# Altium schematic sheet ME5311.SchDoc
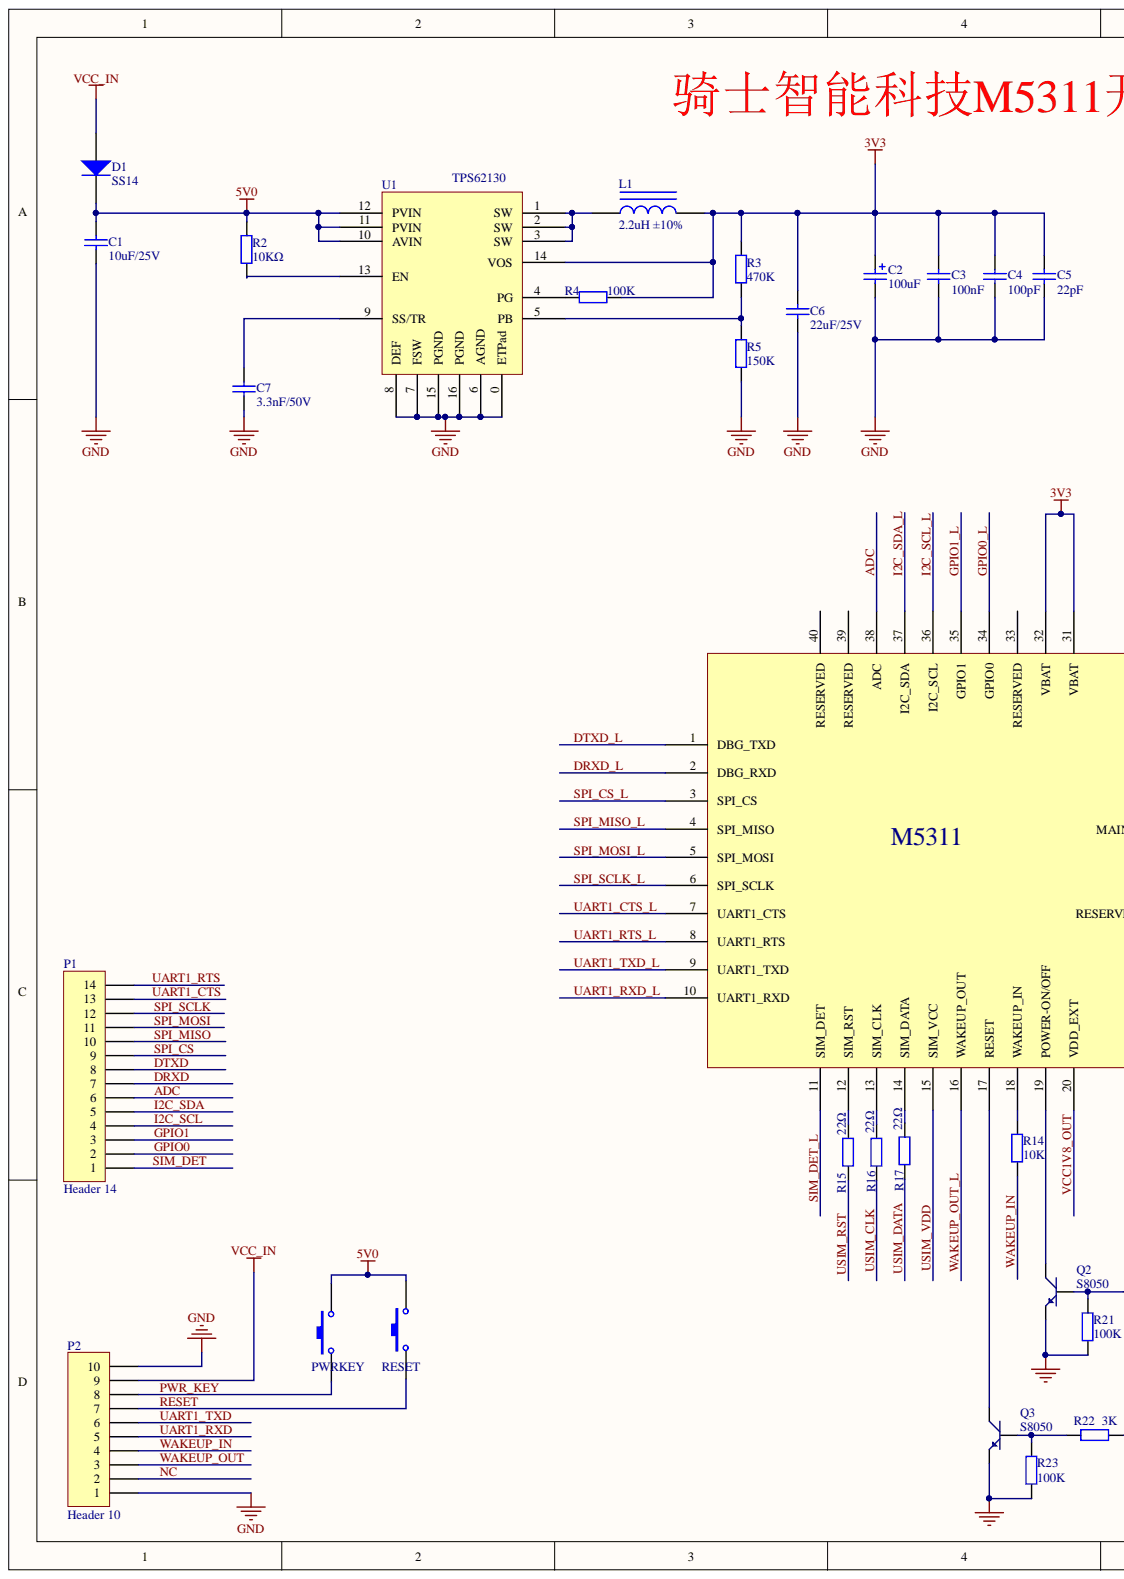
[diagram p0: ME5311.SchDoc, left]
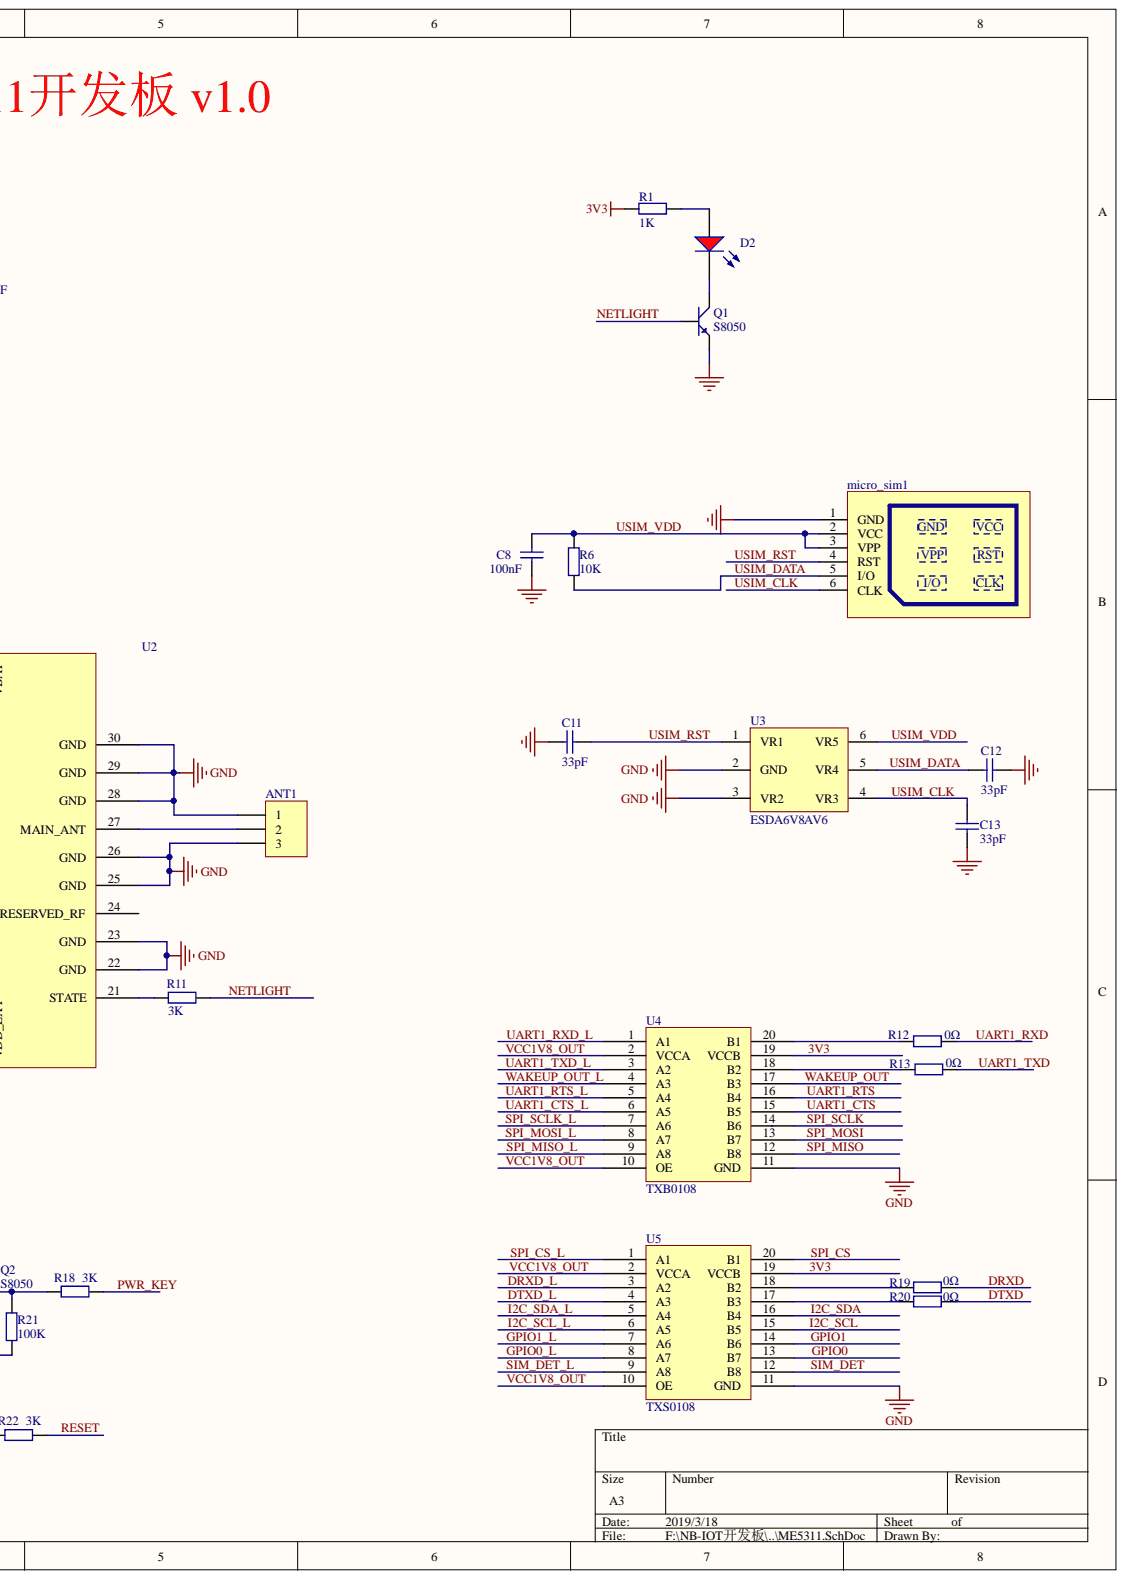
[diagram p1: ME5311.SchDoc, right]

## Parts
ANT1  ANT  [ANT-1]
C1  10uF/25V  [0805_C]
C2  100uF  [CASE-B_3528]
C3  100nF  [0603_C]
C4  100pF  [0603_C]
C5  22pF  [0603_C]
C6  22uF/25V  [0805_C]
C7  3.3nF/50V  [0603_C]
C8  100nF  [0603_C]
C11  33pF  [0603_C]
C12  33pF  [0603_C]
C13  33pF  [0603_C]
D1  SS14  [SMA(DO-214AC)_S1]
D2  红灯 0603  [0603_LED_S1]  (value not drawn)
L1  2.2uH ±10%  [0805_L]
micro_sim1  SIM_Card  [Micro SIM]  (value not drawn)
P1  Header 14  [HDR1X14]
P2  Header 10  [HDR1X10]
PWRKEY  SW-PB  [KEY-3x4_SMD]  (value not drawn)
Q1  S8050  [S8050]
Q2  S8050  [S8050]
Q3  S8050  [S8050]
R1  1K  [0603_R]
R2  10KΩ  [0603_R]
R3  470K  [0603_R]
R4  100K  [0603_R]
R5  150K  [0603_R]
R6  10K  [0603_R]
R11  3K  [0603_R]
R12  0Ω  [0603_R]
R13  0Ω  [0603_R]
R14  10K  [0603_R]
R15  22Ω  [0603_R]
R16  22Ω  [0603_R]
R17  22Ω  [0603_R]
R18  3K  [0603_R]
R19  0Ω  [0603_R]
R20  0Ω  [0603_R]
R21  100K  [0603_R]
R22  3K  [0603_R]
R23  100K  [0603_R]
RESET  SW-PB  [KEY-3x4_SMD]  (value not drawn)
U1  TPS62130  [TPS62130]
U2  M5311  [LCC40]
U3  ESDA6V8AV6  [SOT-563-L]
U4  TXB0108  [LC-TSSOP-20]
U5  TXS0108  [LC-TSSOP-20]

## Nets
5V0: C1.2 D1.2 PWRKEY.2 R2.2 RESET.2 U1.10(AVIN) U1.11(PVIN) U1.12(PVIN)
GND: ANT1.1 ANT1.3 C1.1 C2.2 C3.1 C4.1 C5.1 C6.1 C7.1 C8.1 C11.1 C12.1 C13.1 micro_sim1.1(GND) P2.1 P2.10 Q1.2 Q2.2 Q3.2 R5.1 R21.1 R23.1 U1.0(ETPad) U1.6(AGND) U1.7(FSW) U1.8(DEF) U1.15(PGND) U1.16(PGND) U2.22(GND) U2.23(GND) U2.25(GND) U2.26(GND) U2.28(GND) U2.29(GND) U2.30(GND) U3.2(GND) U3.3(VR2) U4.11(GND) U5.11(GND)
VCC_IN: D1.1 P2.9
3V3: C2.1 C3.2 C4.2 C5.2 C6.2 L1.2 R1.1 R3.2 R4.2 U1.14(VOS) U2.31(VBAT) U2.32(VBAT) U4.19(VCCB) U5.19(VCCB)
ADC: P1.6 U2.38(ADC)
DRXD: P1.7 R19.2
DRXD_L: U2.2(DBG_RXD) U5.3(A2)
DTXD: P1.8 R20.2
DTXD_L: U2.1(DBG_TXD) U5.4(A3)
GPIO0: P1.2 U5.13(B7)
GPIO0_L: U2.34(GPIO0) U5.8(A7)
GPIO1: P1.3 U5.14(B6)
GPIO1_L: U2.35(GPIO1) U5.7(A6)
I2C_SCL: P1.4 U5.15(B5)
I2C_SCL_L: U2.36(I2C_SCL) U5.6(A5)
I2C_SDA: P1.5 U5.16(B4)
I2C_SDA_L: U2.37(I2C_SDA) U5.5(A4)
NC: P2.2
NETLIGHT: Q1.1 R11.2
PWR_KEY: P2.8 PWRKEY.1 R18.2
RESET: P2.7 R22.2 RESET.1
SIM_DET: P1.1 U5.12(B8)
SIM_DET_L: U2.11(SIM_DET) U5.9(A8)
SPI_CS: P1.9 U5.20(B1)
SPI_CS_L: U2.3(SPI_CS) U5.1(A1)
SPI_MISO: P1.10 U4.12(B8)
SPI_MISO_L: U2.4(SPI_MISO) U4.9(A8)
SPI_MOSI: P1.11 U4.13(B7)
SPI_MOSI_L: U2.5(SPI_MOSI) U4.8(A7)
SPI_SCLK: P1.12 U4.14(B6)
SPI_SCLK_L: U2.6(SPI_SCLK) U4.7(A6)
UART1_CTS: P1.13 U4.15(B5)
UART1_CTS_L: U2.7(UART1_CTS) U4.6(A5)
UART1_RTS: P1.14 U4.16(B4)
UART1_RTS_L: U2.8(UART1_RTS) U4.5(A4)
UART1_RXD: P2.5 R12.2
UART1_RXD_L: U2.10(UART1_RXD) U4.1(A1)
UART1_TXD: P2.6 R13.2
UART1_TXD_L: U2.9(UART1_TXD) U4.3(A2)
USIM_CLK: C13.2 micro_sim1.6(CLK) R16.1 U3.4(VR3)
USIM_DATA: C12.2 micro_sim1.5(I/O) R6.1 R17.1 U3.5(VR4)
USIM_RST: C11.2 micro_sim1.4(RST) R15.1 U3.1(VR1)
USIM_VDD: C8.2 micro_sim1.2(VCC) micro_sim1.3(VPP) R6.2 U2.15(SIM_VCC) U3.6(VR5)
VCC1V8_OUT: U2.20(VDD_EXT) U4.2(VCCA) U4.10(OE) U5.2(VCCA) U5.10(OE)
WAKEUP_IN: P2.4 R14.1
WAKEUP_OUT: P2.3 U4.17(B3)
WAKEUP_OUT_L: U2.16(WAKEUP_OUT) U4.4(A3)
NetANT1_2: ANT1.2 U2.27(MAIN_ANT)
NetC7_2: C7.2 U1.9(SS/TR)
NetD2_A: D2.A R1.2
NetD2_K: D2.K Q1.3
NetL1_1: L1.1 U1.1(SW) U1.2(SW) U1.3(SW)
NetQ2_1: Q2.1 R18.1 R21.2
NetQ2_3: Q2.3 U2.19(POWER-ON/OFF)
NetQ3_1: Q3.1 R22.1 R23.2
NetQ3_3: Q3.3 U2.17(RESET)
NetR2_1: R2.1 U1.13(EN)
NetR3_1: R3.1 R5.2 U1.5(PB)
NetR4_1: R4.1 U1.4(PG)
NetR11_1: R11.1 U2.21(STATE)
NetR12_1: R12.1 U4.20(B1)
NetR13_1: R13.1 U4.18(B2)
NetR14_2: R14.2 U2.18(WAKEUP_IN)
NetR15_2: R15.2 U2.12(SIM_RST)
NetR16_2: R16.2 U2.13(SIM_CLK)
NetR17_2: R17.2 U2.14(SIM_DATA)
NetR19_1: R19.1 U5.18(B2)
NetR20_1: R20.1 U5.17(B3)
N00017:

Not connected: U2 24(RESERVED_RF) 33(RESERVED) 39(RESERVED) 40(RESERVED)

## Library data of the parts
ANT1 = Header 3: Header, 3-Pin; Component Kind: Standard; LatestRevisionDate: 17-Jul-2002; LatestRevisionNote: Re-released for DXP Platform.; Library Name: Miscellaneous Connectors.IntLib; Publisher: Altium Limited
C1 = 0805 10uF (106) 10% 25V: 贴片电容; Component Kind: Standard; ComponentLink1Description: 供应商链接; ComponentLink1URL: http://www.szlcsc.com/product/details_16532.html; Library Name: Miscellaneous Devices LC.IntLib; Package: 0805; Supplier: LC; SuppliersPartNumber: C15850
C2 = 3528 100uF (107) 10% 6.3V: 钽电容; Component Kind: Standard; ComponentLink1Description: 供应商链接; ComponentLink1URL: http://www.szlcsc.com/product/details_16815.html; Package: 6032; Supplier: LC; SuppliersPartNumber: C16133; Library Name: 嘉立创.IntLib
C3 C8 = 0603 100nF (104) 10% 50V: 贴片电容; Component Kind: Standard; ComponentLink1Description: 供应商链接; ComponentLink1URL: http://www.szlcsc.com/product/details_15331.html; Package: 0603; Supplier: LC; SuppliersPartNumber: C14663; Library Name: 嘉立创.IntLib
C4 = 0603 100pF (101) 5% 50V: 贴片电容; Component Kind: Standard; ComponentLink1Description: 供应商链接; ComponentLink1URL: http://www.szlcsc.com/product/details_15530.html; Package: 0603; Supplier: LC; SuppliersPartNumber: C14858; Library Name: 嘉立创.IntLib
C5 = 0603 22pF (220) 5% 50V: 贴片电容; Component Kind: Standard; ComponentLink1Description: 供应商链接; ComponentLink1URL: http://www.szlcsc.com/product/details_2005.html; Package: 0603; Supplier: LC; SuppliersPartNumber: C1653; Library Name: 嘉立创.IntLib
C6 = 0805 22uF (226) 20% 25V: 贴片电容; Component Kind: Standard; ComponentLink1Description: 供应商链接; ComponentLink1URL: http://www.szlcsc.com/product/details_46786.html; Library Name: Miscellaneous Devices LC.IntLib; Package: 0805; Supplier: LC; SuppliersPartNumber: C45783
C7 = 0603 3.3nF (332) 10% 50V: 贴片电容; Component Kind: Standard; ComponentLink1Description: 供应商链接; ComponentLink1URL: http://www.szlcsc.com/product/details_1965.html; Library Name: Miscellaneous Devices LC.IntLib; Package: 0603; Supplier: LC; SuppliersPartNumber: C1613
C11-C13 = 0603 33pF (330) 5% 50V: 贴片电容; Component Kind: Standard; ComponentLink1Description: 供应商链接; ComponentLink1URL: http://www.szlcsc.com/product/details_2015.html; Package: 0603; Supplier: LC; SuppliersPartNumber: C1663; Library Name: 嘉立创.IntLib
D1 = SMA(DO-214AC) SS210: 二极管; Component Kind: Standard; ComponentLink1Description: 供应商链接; ComponentLink1URL: http://www.szlcsc.com/product/details_15669.html; Library Name: Miscellaneous Devices LC.IntLib; Package: SMA(DO-214AC); Supplier: LC; SuppliersPartNumber: C14996
D2 = 0603 红灯: 发光二极管; Component Kind: Standard; ComponentLink1Description: 供应商链接; ComponentLink1URL: http://www.szlcsc.com/product/details_2659.html; Package: 0603; Supplier: LC; SuppliersPartNumber: C2286; Library Name: 嘉立创.IntLib
L1 = 0805 2.2uH 10%: 贴片电感; Component Kind: Standard; ComponentLink1Description: 供应商链接; ComponentLink1URL: http://www.szlcsc.com/product/details_1395.html; Library Name: Miscellaneous Devices LC.IntLib; Package: 0805; Supplier: LC; SuppliersPartNumber: C1043
P1 = Header 14: Header, 14-Pin; Component Kind: Standard; LatestRevisionDate: 17-Jul-2002; LatestRevisionNote: Re-released for DXP Platform.; Library Name: Miscellaneous Connectors.IntLib; Signal Integrity: Connector; Publisher: Altium Limited
P2 = Header 10: Header, 10-Pin; Component Kind: Standard; LatestRevisionDate: 17-Jul-2002; LatestRevisionNote: Re-released for DXP Platform.; Library Name: Miscellaneous Connectors.IntLib; Signal Integrity: Connector; Publisher: Altium Limited
PWRKEY RESET = SW-PB_1: Switch; Component Kind: Standard; LatestRevisionDate: 17-Jul-2002; LatestRevisionNote: Re-released for DXP Platform.; PackageReference: SPST-2; Published: 24-Mar-1999; Library Name: longmain.SchLib; Publisher: Altium Limited
Q1-Q3 = S8050-NPN: 8050/BCW846/BCW847; Component Kind: Standard; Library Name: Schlib1.SchLib
R1 = 0603 1KΩ (1001) 1%: 贴片电阻; Component Kind: Standard; ComponentLink1Description: 供应商链接; ComponentLink1URL: http://www.szlcsc.com/product/details_21904.html; Package: 0603; Supplier: LC; SuppliersPartNumber: C21190; Library Name: 嘉立创.IntLib
R2 = 0603 0Ω (0R0) 1%: 贴片电阻; Component Kind: Standard; ComponentLink1Description: 供应商链接; ComponentLink1URL: http://www.szlcsc.com/product/details_21903.html; Package: 0603; Supplier: LC; SuppliersPartNumber: C21189; Library Name: 嘉立创.IntLib
R3 = 0603 470KΩ (4703) 1%: 贴片电阻; Component Kind: Standard; ComponentLink1Description: 供应商链接; ComponentLink1URL: http://www.szlcsc.com/product/details_23905.html; Library Name: Miscellaneous Devices LC.IntLib; Package: 0603; Supplier: LC; SuppliersPartNumber: C23178
R4 R21 R23 = 0603 100KΩ (1003) 1%: 贴片电阻; Component Kind: Standard; ComponentLink1Description: 供应商链接; ComponentLink1URL: http://www.szlcsc.com/product/details_26546.html; Package: 0603; Supplier: LC; SuppliersPartNumber: C25803
  R4: Library Name: Miscellaneous Devices LC.IntLib
  R21 R23: Library Name: 嘉立创.IntLib
R5 = 0603 150KΩ (1503) 1%: 贴片电阻; Component Kind: Standard; ComponentLink1Description: 供应商链接; ComponentLink1URL: http://www.szlcsc.com/product/details_23534.html; Library Name: Miscellaneous Devices LC.IntLib; Package: 0603; Supplier: LC; SuppliersPartNumber: C22807
R6 R14 = 0603 10KΩ (1002) 1%: 贴片电阻; Component Kind: Standard; ComponentLink1Description: 供应商链接; ComponentLink1URL: http://www.szlcsc.com/product/details_26547.html; Package: 0603; Supplier: LC; SuppliersPartNumber: C25804
  R14: Library Name: Miscellaneous Devices LC.IntLib
  R6: Library Name: 嘉立创.IntLib
R11 R18 R22 = 0603 3KΩ (3001) 1%: 贴片电阻; Component Kind: Standard; ComponentLink1Description: 供应商链接; ComponentLink1URL: http://www.szlcsc.com/product/details_4618.html; Package: 0603; Supplier: LC; SuppliersPartNumber: C4211; Library Name: 嘉立创.IntLib
R12 R13 R19 R20 = 0603 510Ω (5100) 1%: 贴片电阻; Component Kind: Standard; ComponentLink1Description: 供应商链接; ComponentLink1URL: http://www.szlcsc.com/product/details_23920.html; Library Name: Miscellaneous Devices LC.IntLib; Package: 0603; Supplier: LC; SuppliersPartNumber: C23193
R15-R17 = 0603 22Ω (22R0) 1%: 贴片电阻; Component Kind: Standard; ComponentLink1Description: 供应商链接; ComponentLink1URL: http://www.szlcsc.com/product/details_24078.html; Package: 0603; Supplier: LC; SuppliersPartNumber: C23345; Library Name: 嘉立创.IntLib
U2 = M5311-BIG: Component Kind: Standard; Library Name: LCC-18X16-40PIN-M5311.SchLib
U5 = TXB0108: Component Kind: Standard; Library Name: longmain.SchLib
micro_sim1 = SIM_Card: Component Kind: Standard; Library Name: MySchLibrary.SchLib
U1 = TPS62130: Component Kind: Standard; Library Name: longmain.SchLib
U3 = ESDA6V8AV6: Component Kind: Standard; Library Name: longmain.SchLib
U4 = TXB0108: Component Kind: Standard; Library Name: longmain.SchLib
